# Altium schematic sheet Mini3s.SchDoc(Mini3s)
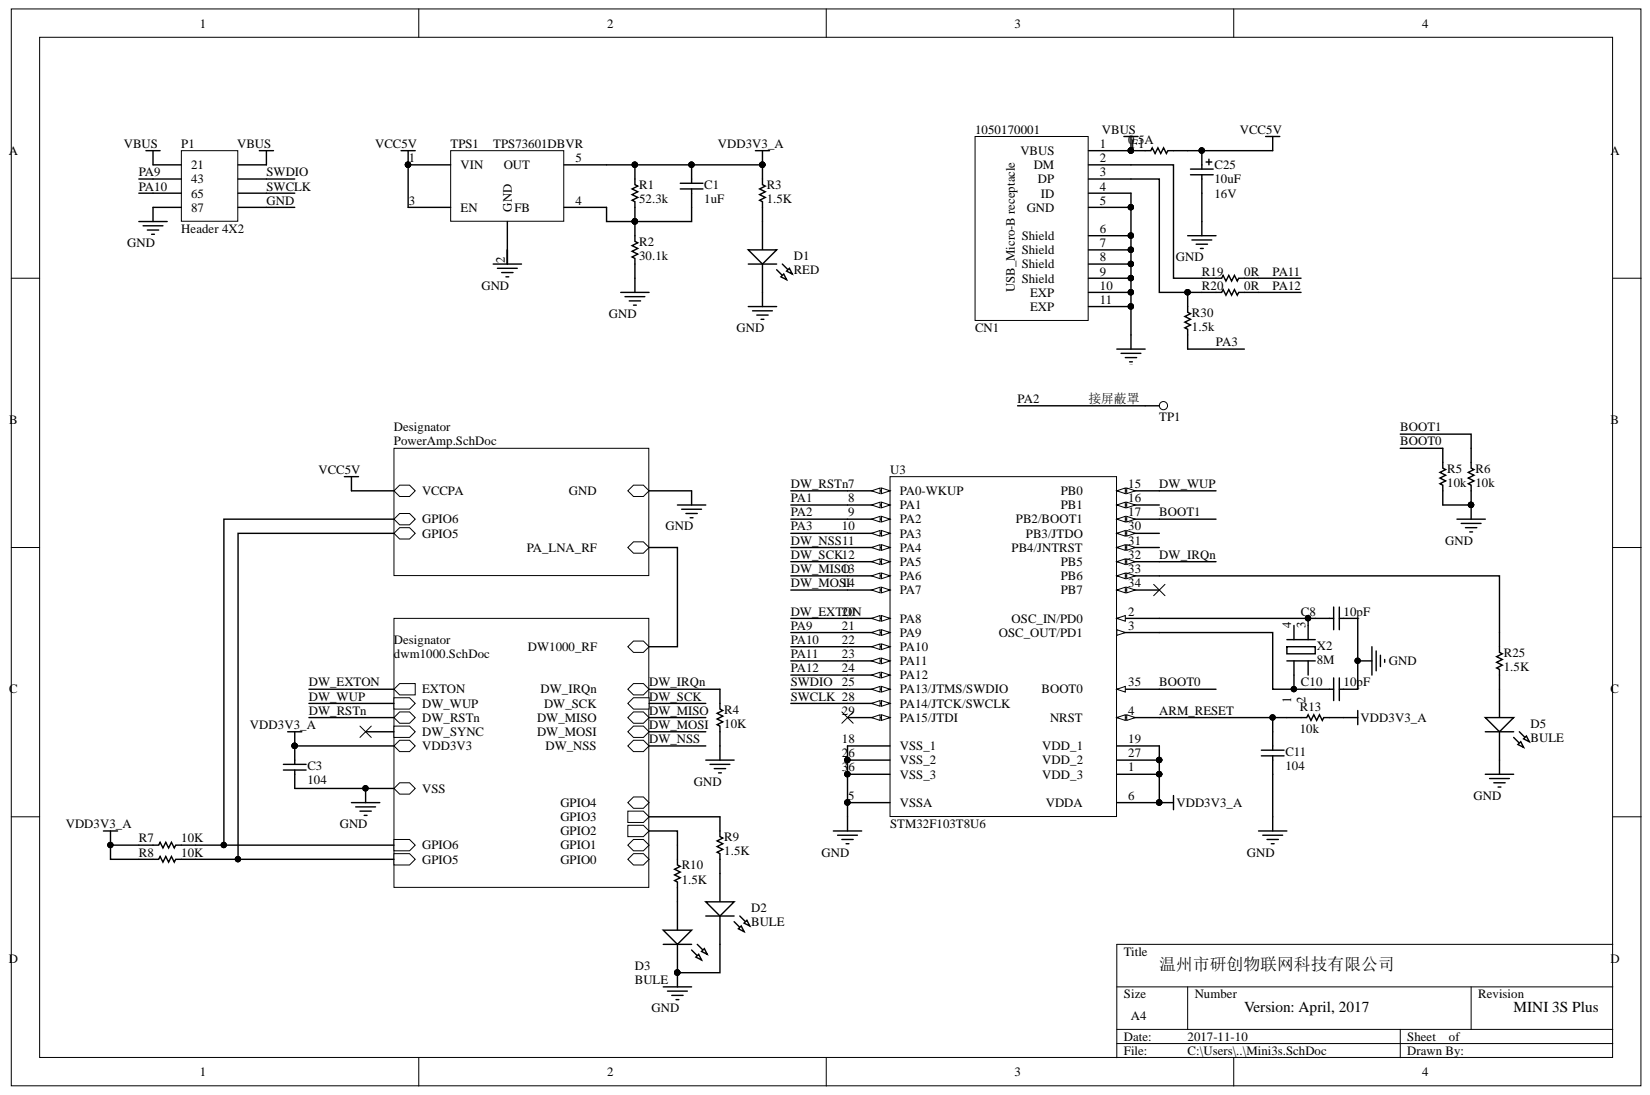
[diagram p0: Mini3s.SchDoc]

## Parts
C1  1uF  [LC-0402_C]
C3  value 104  [LC-0402_C]  (hidden comment: 100NF)
C8  10pF  [LC-0402_C]
C10  10pF  [LC-0402_C]
C11  value 104  [LC-0402_C]  (hidden comment: 100NF)
C25  10uF  Voltage: 16V  [TAN-A-3216]
CN1  1050170001  [Molex_1050170001]
D1  RED  [0603D]
D2  BULE  [0603D]
D3  BULE  [0603D]
D5  BULE  [0603D]
F1  0.5A  [CR2012-0805]
P1  Header 4X2  [HDR2X4]
R1  52.3K  [LC-0402_R]
R2  30.1K  [LC-0402_R]
R3  1.5K  [LC-0402_R]
R4  10K  [LC-0402_R]
R5  10K  [LC-0402_R]
R6  10K  [LC-0402_R]
R7  10K  [LC-0402_R]
R8  10K  [LC-0402_R]
R9  1.5K  [LC-0402_R]
R10  1.5K  [LC-0402_R]
R13  10K  [LC-0402_R]
R19  0R  [LC-0402_R]
R20  0R  [LC-0402_R]
R25  1.5K  [LC-0402_R]
R30  1.5K  [LC-0402_R]
TP1  Test-Point  [TP]  (value not drawn)
TPS1  TPS73601DBVR  [LC-SOT-23-5]
U3  STM32F103T8U6  [VFQN36_V-引脚长]
X2  8M  [3225]

## Nets
VBUS: CN1.1 F1.1 P1.1 P1.2(2_1)
VCC5V: C25.2 F1.2 TPS1.1(VIN) TPS1.3(EN)
VDD3V3_A: C1.2 C3.2 R1.2 R3.2 R7.1 R8.1 R13.2 TPS1.5 U3.1 U3.6 U3.19 U3.27
ARM_RESET: C11.2 R13.1 U3.4
BOOT0: R5.1 U3.35
BOOT1: R6.1 U3.17
DW_EXTON: U3.20(PA8)
DW_IRQN: R4.2 U3.32
DW_MISO: U3.13(PA6)
DW_MOSI: U3.14(PA7)
DW_NSS: U3.11(PA4)
DW_RSTN: U3.7(PA0-WKUP)
DW_SCK: U3.12(PA5)
DW_WUP: U3.15
GND: C3.1 C8.1 C10.1 C11.1 C25.1 CN1.4 CN1.5 CN1.6 CN1.7 CN1.8 CN1.9 CN1.10 CN1.11 D1.2 D2.2 D3.2 D5.2 P1.7 P1.8(8_7) R2.1 R4.1 R5.2 R6.2 TPS1.2(GND) U3.5(VSSA) U3.18(VSS_1) U3.26(VSS_2) U3.36(VSS_3)
PA1: U3.8(PA1)
PA2: TP1.1 U3.9(PA2)
PA3: R30.1 U3.10(PA3)
PA9: P1.4(4_3) U3.21(PA9)
PA10: P1.6(6_5) U3.22(PA10)
PA11: R19.2 U3.23(PA11)
PA12: R20.2 U3.24(PA12)
SWCLK: P1.5 U3.28(PA14/JTCK/SWCLK)
SWDIO: P1.3 U3.25(PA13/JTMS/SWDIO)
NetC1_1: C1.1 R1.1 R2.2 TPS1.4
NetC8_2: C8.2 U3.2 X2.3
NetC10_2: C10.2 U3.3 X2.1
NetCN1_2: CN1.2 R19.1
NetCN1_3: CN1.3 R20.1 R30.2
NetD1_1: D1.1 R3.1
NetD2_1: D2.1 R9.1
NetD3_1: D3.1 R10.1
NetD5_1: D5.1 R25.1
NetR7_2: R7.2
NetR8_2: R8.2
NetR9_2: R9.2
NetR10_2: R10.2
NetR25_2: R25.2 U3.33
NetU3_16: U3.16
NetU3_29: U3.29(PA15/JTDI)
NetU3_30: U3.30
NetU3_31: U3.31
NetU3_34: U3.34
NetX2_2: X2.2
NetX2_4: X2.4
DW1000_RF:
DW_SYNC:
GPIO0:
GPIO1:
GPIO4:

## Library data of the parts
C1 C3 C8 C10 C11 = Cap Chip 0402: Polarized Capacitor (Radial); Component Kind: Standard; Published: 8-Jun-2000; Revision: July-2002: Re-released for DXP Platform.; Library Name: LisieLib_Ultimate.IntLib; Publisher: Altium Limited
  C1: Value: 1uF
  C8 C10: Value: 10pF
C25 = Cap Tan A: Polarized Capacitor (Radial); Component Kind: Standard; PCB3D: TAJ-A; Published: 8-Jun-2000; Revision: July-2002: Re-released for DXP Platform.; Value: 10uF; Library Name: LisieLib_Ultimate.IntLib; Publisher: Altium Limited
CN1 = 1050170001: Silkscreen: USB; Component Kind: Standard; Library Name: UWB_Mini3.IntLib
D1-D3 D5 = LED0: Typical INFRARED GaAs LED; Component Kind: Standard; LatestRevisionDate: 08-Jul-2005; LatestRevisionNote: Mech Layer 1 removed.; Library Name: Miscellaneous Devices.IntLib; PackageDescription: LED; 2 Leads; PackageReference: LED-0; Published: 8-Jun-2000; Simulation: LED0; Publisher: Altium Limited
F1 = Res1: Resistor; Component Kind: Standard; LatestRevisionDate: 17-Jul-2002; LatestRevisionNote: Re-released for DXP Platform.; Library Name: Miscellaneous Devices.IntLib; PackageDescription: Axial Device, Thru-Hole; 2 Leads; 0.3 in Pin Spacing; PackageReference: AXIAL-0.3; Published: 8-Jun-2000; Value: 0.5A; Publisher: Altium Limited
P1 = Header 4X2: Header, 4-Pin, Dual row; Component Kind: Standard; LatestRevisionDate: 17-Jul-2002; LatestRevisionNote: Re-released for DXP Platform.; Library Name: LisieLib_Ultimate.IntLib; Publisher: Altium Limited
R1-R10 R13 R19 R20 R25 R30 = Resistor Chip 0402: Resistor; Component Kind: Standard; Published: 8-Jun-2000; Revision: July-2002: Re-released for DXP Platform.; Library Name: LisieLib_Ultimate.IntLib; Publisher: Altium Limited
  R1: Value: 52.3k
  R2: Value: 30.1k
  R3 R9 R10 R25: Value: 1.5K
  R4 R7 R8: Value: 10K
  R5 R6 R13: Value: 10k
  R19 R20: Value: 0R
  R30: Value: 1.5k
U3 = STM32F103T8U6: STM32 ARM-based 32-bit MCU with 64 Kbytes Flash, 36-pin VFQFPN, Industrial Temperature; Component Kind: Standard; ComponentLink1Description: Manufacturer Link; ComponentLink1URL: www.st.com/stm32; ComponentLink2Description: Datasheet; ComponentLink2URL: http://www.st.com/stonline/products/literature/ds/13587.pdf; DatasheetVersion: rev6, Mar-2008; Library Name: ST Microcontroller 32-Bit STM32.SchLib; PackageDescription: 36-Pin Quad Flat No-Lead, 6 x 6 X 1.0 mm Body, 0.5 mm Pitch, Exposed Pad (VFQN36); PackageReference: VFQN36; PackageVersion: Mar-2008; Published: 30-May-2008; Publisher: Altium Limited
X2 = XTAL: Component Kind: Standard; Library Name: Schlib1.SchLib
TP1 = Test-Point: Component Kind: Standard; Description: Test-Point; Library Name: LisieLib_Ultimate.IntLib
TPS1 = TPS73601DBVR: Component Kind: Standard; Library Name: mylib.SchLib
